# Altium schematic sheet Sway Rev C - Sheet 2.SchDoc("Sway Rev C - Sheet 2")
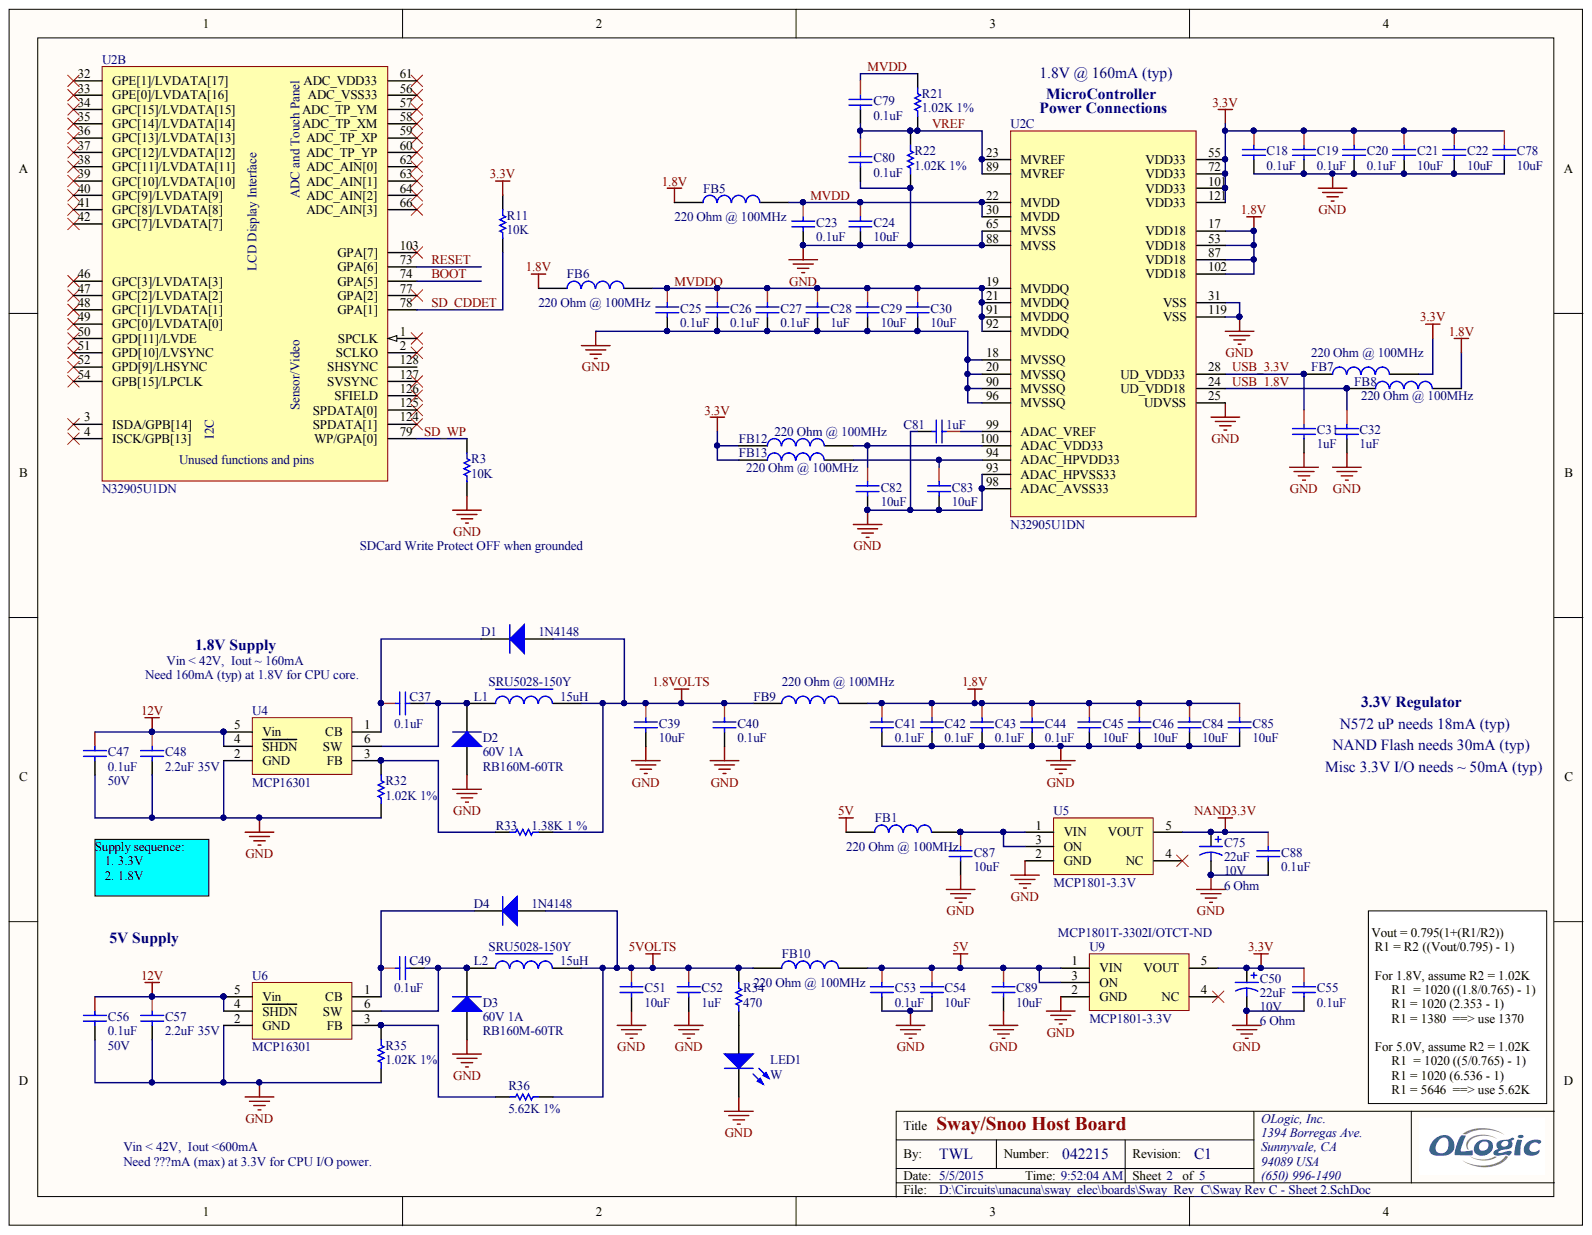
[diagram p0: Sway Rev C - Sheet 2.SchDoc]

## Parts
C18  0.1uF  [CAPC0603(1608)_L]
C19  0.1uF  [CAPC0603(1608)_L]
C20  0.1uF  [CAPC0603(1608)_L]
C21  10uF  [CAPC0805(2012)_L]
C22  10uF  [CAPC0805(2012)_L]
C23  0.1uF  [CAPC0603(1608)_L]
C24  10uF  [CAPC0805(2012)_L]
C25  0.1uF  [CAPC0603(1608)_L]
C26  0.1uF  [CAPC0603(1608)_L]
C27  0.1uF  [CAPC0603(1608)_L]
C28  1uF  [CAPC0603(1608)_L]
C29  10uF  [CAPC0805(2012)_L]
C30  10uF  [CAPC0805(2012)_L]
C31  1uF  [CAPC0603(1608)_L]
C32  1uF  [CAPC0603(1608)_L]
C37  0.1uF  [CAPC0603(1608)_L]
C39  10uF  [CAPC0805(2012)_L]
C40  0.1uF  [CAPC0603(1608)_L]
C41  0.1uF  [CAPC0603(1608)_L]
C42  0.1uF  [CAPC0603(1608)_L]
C43  0.1uF  [CAPC0603(1608)_L]
C44  0.1uF  [CAPC0603(1608)_L]
C45  10uF  [CAPC0805(2012)_L]
C46  10uF  [CAPC0805(2012)_L]
C47  0.1uF  Voltage - Rated: 50V  [CAPC0603(1608)_N]
C48  2.2uF 35V  [CAPC1210(3225)_L]
C49  0.1uF  [CAPC0603(1608)_L]
C50  22uF  Voltage - Rated: 10V  [TANT-3216]
C51  10uF  [CAPC0805(2012)_L]
C52  1uF  [CAPC0603(1608)_L]
C53  0.1uF  [CAPC0603(1608)_L]
C54  10uF  [CAPC0805(2012)_L]
C55  0.1uF  [CAPC0603(1608)_L]
C56  0.1uF  Voltage - Rated: 50V  [CAPC0603(1608)_N]
C57  2.2uF 35V  [CAPC1210(3225)_L]
C75  22uF  ClassName: NAND3.3V  Voltage - Rated: 10V  [TANT-3216]
C78  10uF  [CAPC0805(2012)_L]
C79  0.1uF  [CAPC0603(1608)_L]
C80  0.1uF  [CAPC0603(1608)_L]
C81  1uF  [CAPC0603(1608)_L]
C82  10uF  [CAPC0805(2012)_L]
C83  10uF  [CAPC0805(2012)_L]
C84  10uF  [CAPC0805(2012)_L]
C85  10uF  [CAPC0805(2012)_L]
C87  10uF  [CAPC0805(2012)_L]
C88  0.1uF  [CAPC0603(1608)_L]
C89  10uF  [CAPC0805(2012)_L]
D1  1N4148  [SOD123]
D2  60V 1A  Manufacturer Part Number: RB160M-60TR  Voltage - DC Reverse (Vr) (Max): 60V  [SOD123]
D3  60V 1A  Manufacturer Part Number: RB160M-60TR  Voltage - DC Reverse (Vr) (Max): 60V  [SOD123]
D4  1N4148  [SOD123]
FB1  220 Ohm @ 100MHz  [RES-1608[0603]]
FB5  220 Ohm @ 100MHz  [RES-1608[0603]]
FB6  220 Ohm @ 100MHz  [RES-1608[0603]]
FB7  220 Ohm @ 100MHz  [RES-1608[0603]]
FB8  220 Ohm @ 100MHz  [RES-1608[0603]]
FB9  220 Ohm @ 100MHz  [RES-1608[0603]]
FB10  220 Ohm @ 100MHz  [RES-1608[0603]]
FB12  220 Ohm @ 100MHz  [RES-1608[0603]]
FB13  220 Ohm @ 100MHz  [RES-1608[0603]]
L1  15uH  Manufacturer Part Number: SRU5028-150Y  [INDUCTOR 5.2x5.2mm SMD]
L2  15uH  Manufacturer Part Number: SRU5028-150Y  [INDUCTOR 5.2x5.2mm SMD]
LED1  W  [SM-LED-0603]
R3  10K  [RESC0603(1608)_N]
R11  10K  [RESC0603(1608)_N]
R21  1.02K 1%  [RESC0603(1608)_N]
R22  1.02K 1%  [RESC0603(1608)_N]
R32  1.02K 1%  [RESC0603(1608)_L]
R33  1.38K  Tolerance: 1 %  [RESC0603(1608)_L]
R34  470  [RESC0603(1608)_L]
R35  1.02K 1%  [RESC0603(1608)_L]
R36  5.62K 1%  [RESC0603(1608)_L]
U2  N32905U1DN  [LQFP128]  (parts B,C)
U4  MCP16301  [SOT23-6]
U5  MCP1801-3.3V  ClassName: NAND3.3V  [SOT23-5]
U6  MCP16301  [SOT23-6]
U9  MCP1801-3.3V  PN: MCP1801T-3302I/OTCT-ND  [SOT23-5]

## Nets
1.8V: C41.1 C42.1 C43.1 C44.1 C45.1 C46.1 C84.1 C85.1 FB5.1 FB6.1 FB8.2 FB9.2 U2.17(VDD18) U2.53(VDD18) U2.87(VDD18) U2.102(VDD18)
1.8VOLTS: C39.1 C40.1 D1.1 FB9.1 L1.3 R33.1
3.3V: C18.1 C19.1 C20.1 C21.1 C22.1 C50.1 C55.1 C78.1 FB7.2 FB12.1 FB13.1 R11.2 U2.55(VDD33) U2.72(VDD33) U2.101(VDD33) U2.121(VDD33) U9.5(VOUT)
5V: C53.1 C54.1 C89.1 FB1.1 FB10.2 U9.1(VIN) U9.3(ON)
5VOLTS: C51.1 C52.1 D4.1 FB10.1 L2.3 R34.2 R36.1
12V: C47.1 C48.1 C56.1 C57.1 U4.4(SHDN) U4.5(Vin) U6.4(SHDN) U6.5(Vin)
GND: C18.2 C19.2 C20.2 C21.2 C22.2 C23.2 C24.2 C25.2 C26.2 C27.2 C28.2 C29.2 C30.2 C31.2 C32.2 C39.2 C40.2 C41.2 C42.2 C43.2 C44.2 C45.2 C46.2 C47.2 C48.2 C50.2 C51.2 C52.2 C53.2 C54.2 C55.2 C56.2 C57.2 C75.2 C78.2 C80.2 C81.2 C82.2 C83.2 C84.2 C85.2 C87.2 C88.2 C89.2 D2.1 D3.1 LED1.2 R3.1 R22.1 R32.1 R35.1 U2.18(MVSSQ) U2.20(MVSSQ) U2.25(UDVSS) U2.31(VSS) U2.65(MVSS) U2.88(MVSS) U2.90(MVSSQ) U2.93(ADAC_HPVSS33) U2.96(MVSSQ) U2.98(ADAC_AVSS33) U2.119(VSS) U4.2(GND) U5.2(GND) U6.2(GND) U9.2(GND)
NAND3.3V: C75.1 C88.1 U5.5(VOUT)
BOOT: U2.74(GPA[5])
MVDD: C23.1 C24.1 C79.1 FB5.2 R21.2 U2.22(MVDD) U2.30(MVDD)
MVDDQ: C25.1 C26.1 C27.1 C28.1 C29.1 C30.1 FB6.2 U2.19(MVDDQ) U2.21(MVDDQ) U2.91(MVDDQ) U2.92(MVDDQ)
RESET: U2.73(GPA[6])
SD_CDDET: R11.1 U2.78(GPA[1])
SD_WP: R3.2 U2.79(WP/GPA[0])
USB_1.8V: C32.1 FB8.1 U2.24(UD_VDD18)
USB_3.3V: C31.1 FB7.1 U2.28(UD_VDD33)
VREF: C79.2 C80.1 R21.1 R22.2 U2.23(MVREF) U2.89(MVREF)
NetC37_1: C37.1 D1.2 U4.1(CB)
NetC37_2: C37.2 D2.2 L1.1 U4.6(SW)
NetC49_1: C49.1 D4.2 U6.1(CB)
NetC49_2: C49.2 D3.2 L2.1 U6.6(SW)
NetC81_1: C81.1 U2.99(ADAC_VREF)
NetC82_1: C82.1 FB12.2 U2.100(ADAC_VDD33)
NetC83_1: C83.1 FB13.2 U2.94(ADAC_HPVDD33)
NetC87_1: C87.1 FB1.2 U5.1(VIN) U5.3(ON)
NetLED1_1: LED1.1 R34.1
NetR32_2: R32.2 R33.2 U4.3(FB)
NetR35_2: R35.2 R36.2 U6.3(FB)

Not connected: U2 1(SPCLK) 2(SCLKO) 3(ISDA/GPB[14]) 4(ISCK/GPB[13]) 32(GPE[1]/LVDATA[17]) 33(GPE[0]/LVDATA[16]) 34(GPC[15]/LVDATA[15]) 35(GPC[14]/LVDATA[14]) 36(GPC[13]/LVDATA[13]) 37(GPC[12]/LVDATA[12]) 38(GPC[11]/LVDATA[11]) 39(GPC[10]/LVDATA[10]) 40(GPC[9]/LVDATA[9]) 41(GPC[8]/LVDATA[8]) 42(GPC[7]/LVDATA[7]) 46(GPC[3]/LVDATA[3]) 47(GPC[2]/LVDATA[2]) 48(GPC[1]/LVDATA[1]) 49(GPC[0]/LVDATA[0]) 50(GPD[11]/LVDE) 51(GPD[10]/LVSYNC) 52(GPD[9]/LHSYNC) 54(GPB[15]/LPCLK) 56(ADC_VSS33) 57(ADC_TP_YM) 58(ADC_TP_XM) 59(ADC_TP_XP) 60(ADC_TP_YP) 61(ADC_VDD33) 62(ADC_AIN[0]) 63(ADC_AIN[1]) 64(ADC_AIN[2]) 66(ADC_AIN[3]) 77(GPA[2]) 103(GPA[7]) 124(SPDATA[1]) 125(SPDATA[0]) 126(SFIELD) 127(SVSYNC) 128(SHSYNC); U5 4(NC); U9 4(NC)

## Library data of the parts
C18-C20 C23 C25-C27 C37 C40-C44 C49 C53 C55 C79 C80 C88 = CAP CER 0.1UF 16V 10% X7R 0603: 0.1uF 0603; Manufacturer: Kemet; Manufacturer Part Number: C0603C104K4RACTU; Capacitance: 0.1µF; Temperature Coefficient: X7R; Tolerance: ±10%; Voltage - Rated: 16V; Applications: General Purpose; Category: Capacitors; Component Kind: Standard; ComponentLink1Description: http://www.kemet.com/datasheets&C0603C104K4RACTU; ComponentLink1URL: http://www.kemet.com/datasheets&C0603C104K4RACTU; Features: -; Height - Seated (Max): -; Lead Spacing: -; Lead Style: -; Library Name: OLogic-Passive.IntLib; Mounting Type: Surface Mount, MLCC; Operating Temperature: -55°C ~ 125°C; Package / Case: 0603 (1608 Metric); Packaging: Tape & Reel (TR); Pricing 1: 4000=0.00414, 8000=0.00378, 12000=0.0036, 28000=0.00342, 100000=0.00248 (USD); Ratings: -; RoHS: RoHS Compliant; Size / Dimension: 0.063" L x 0.031" W (1.60mm x 0.80mm); Stock 1: 2360000; Supplier 1: Digi-Key; Supplier Part Number 1: 399-1096-2-ND; Thickness (Max): 0.034" (0.87mm)
  C18-C20 C23 C25-C27 C41-C44 C55 C79 C80 C88: ClassName: UP
  C37 C49 C53: ClassName: PWR
C21 C22 C24 C29 C30 C39 C45 C46 C51 C54 C78 C82-C85 C87 C89 = CAP CER 10UF 10V Y5V 0805: 10uF 0805; Manufacturer: Taiyo Yuden; Manufacturer Part Number: LMK212F106ZG-T; Capacitance: 10µF; Temperature Coefficient: Y5V (F); Tolerance: -20%, +80%; Voltage - Rated: 10V; Applications: General Purpose; Category: Capacitors; Component Kind: Standard; ComponentLink1Description: http://www.yuden.co.jp/or/product/category/capacitor/LMK212F106ZG-T.pdf; ComponentLink1URL: http://www.yuden.co.jp/or/product/category/capacitor/LMK212F106ZG-T.pdf; Features: -; Height - Seated (Max): -; Lead Spacing: -; Lead Style: -; Library Name: OLogic-Passive.IntLib; Mounting Type: Surface Mount, MLCC; Operating Temperature: -30°C ~ 85°C; Package / Case: 0805 (2012 Metric); Packaging: Cut Tape (CT); Pricing 1: 1=0.17, 10=0.115, 50=0.0662, 100=0.0546, 250=0.046, 500=0.03852, 1000=0.03163 (USD); Ratings: -; RoHS: RoHS Compliant; Size / Dimension: 0.079" L x 0.049" W (2.00mm x 1.25mm); Stock 1: 194456; Supplier 1: Digi-Key; Supplier Part Number 1: 587-1312-1-ND; Thickness (Max): 0.053" (1.35mm)
  C21 C22 C24 C29 C30 C45 C46 C78 C82-C85: ClassName: UP
  C39 C51 C54: ClassName: PWR
  C87: ClassName: NAND3.3V
  C89: ClassName: 3_3V
C28 C31 C32 C52 C81 = CAP CER 1UF 10V 10% X5R 0603: 1uF 0603; Manufacturer: Kemet; Manufacturer Part Number: C0603C105K8PACTU; Capacitance: 1.0µF; Temperature Coefficient: X5R; Tolerance: ±10%; Voltage - Rated: 10V; Applications: General Purpose; Category: Capacitors; Component Kind: Standard; ComponentLink1Description: http://www.kemet.com/datasheets&C0603C105K8PACTU; ComponentLink1URL: http://www.kemet.com/datasheets&C0603C105K8PACTU; Features: -; Height - Seated (Max): -; Lead Spacing: -; Lead Style: -; Library Name: OLogic-Passive.IntLib; Mounting Type: Surface Mount, MLCC; Operating Temperature: -55°C ~ 85°C; Package / Case: 0603 (1608 Metric); Packaging: Cut Tape (CT); Pricing 1: 1=0.1, 10=0.043, 25=0.0304, 50=0.0232, 100=0.0196, 250=0.01608, 1000=0.0105 (USD); Ratings: -; RoHS: RoHS Compliant; Size / Dimension: 0.063" L x 0.031" W (1.60mm x 0.80mm); Stock 1: 1121198; Supplier 1: Digi-Key; Supplier Part Number 1: 399-3118-1-ND; Thickness (Max): 0.035" (0.90mm)
  C28 C31 C32 C81: ClassName: UP
  C52: ClassName: PWR
C47 C56 = CAP CER 0.1UF 50V 10% X7R 0603: 0.1UF 50V 0603; Manufacturer: Kemet; Manufacturer Part Number: C0603C104K5RACTU; Capacitance: 0.1µF; Temperature Coefficient: X7R; Tolerance: ±10%; Applications: General Purpose; Category: Capacitors; Component Kind: Standard; ComponentLink1Description: http://www.kemet.com/docfinder?Partnumber=C0603C104K5RACTU; ComponentLink1URL: http://www.kemet.com/docfinder?Partnumber=C0603C104K5RACTU; Features: -; Height - Seated (Max): -; Lead Spacing: -; Lead Style: -; Library Name: OLogic-Passive.IntLib; Mounting Type: Surface Mount, MLCC; Operating Temperature: -55°C ~ 125°C; Package / Case: 0603 (1608 Metric); Packaging: Cut Tape (CT); Pricing 1: 1=0.1, 10=0.041, 50=0.0222, 100=0.0187, 250=0.01532, 500=0.0131, 1000=0.0102 (USD); Ratings: -; RoHS: RoHS Compliant; Size / Dimension: 0.063" L x 0.031" W (1.60mm x 0.80mm); Stock 1: 1397942; Supplier 1: Digi-Key; Supplier Part Number 1: 399-5089-1-ND; Thickness (Max): 0.034" (0.87mm)
C48 C57 = CAP CER 2.2UF 35V 10% X5R 1210: 2.2uF 1210; Manufacturer: Taiyo Yuden; Manufacturer Part Number: GMK325BJ225KN-T; Capacitance: 2.2µF; Temperature Coefficient: X5R; Tolerance: ±10%; Applications: General Purpose; Category: Capacitors; ClassName: PWR; Component Kind: Standard; ComponentLink1Description: http://www.yuden.co.jp/productdata/catalog/en/mlcc101_e.pdf; ComponentLink1URL: http://www.yuden.co.jp/productdata/catalog/en/mlcc101_e.pdf; Features: -; Height - Seated (Max): -; Lead Spacing: -; Lead Style: -; Library Name: OLogic-Passive.IntLib; Mounting Type: Surface Mount, MLCC; Operating Temperature: -55°C ~ 85°C; Package / Case: 1210 (3225 Metric); Packaging: Cut Tape (CT); Pricing 1: 1=0.38, 10=0.329, 50=0.1998, 100=0.1645, 250=0.1316, 500=0.11986, 1000=0.1081 (USD); Ratings: -; RoHS: RoHS Compliant; Size / Dimension: 0.126" L x 0.098" W (3.20mm x 2.50mm); Stock 1: 72591; Supplier 1: Digi-Key; Supplier Part Number 1: 587-1372-1-ND; Thickness (Max): 0.083" (2.10mm); Voltage - Rated: 35V
C50 C75 = CAP TANT 22UF 10V 20% 1206: 22uF 3216 10V 6 ohms; Manufacturer: Kemet; Manufacturer Part Number: T491A226M010AT; Manufacturer Size Code: A; Capacitance: 22µF; ESR (Equivalent Series Resistance): 6 Ohm; Tolerance: ±20%; Type: Molded; Category: Capacitors; Component Kind: Standard; ComponentLink1Description: http://www.kemet.com/kemet/web/homepage/kechome.nsf/file/T491%20Series/$file/KEM_T2005_T491.pdf; ComponentLink1URL: http://www.kemet.com/kemet/web/homepage/kechome.nsf/file/T491%20Series/$file/KEM_T2005_T491.pdf; Features: General Purpose; Height - Seated (Max): 0.071" (1.80mm); Lead Spacing: -; Library Name: OLogic-Passive.IntLib; Lifetime @ Temp.: -; Mounting Type: Surface Mount; Operating Temperature: -55°C ~ 125°C; Package / Case: 1206 (3216 Metric); Packaging: Cut Tape (CT); Pricing 1: 1=0.75, 10=0.714, 25=0.5064, 50=0.4264, 100=0.3464, 250=0.2878, 1000=0.23513 (USD); RoHS: RoHS Compliant; Size / Dimension: 0.126" L x 0.063" W (3.20mm x 1.60mm); Stock 1: 32923; Supplier 1: Digi-Key; Supplier Part Number 1: 399-3692-1-ND
  C50: ClassName: 3_3V
D1 D4 = 1N4148WS: DIODE SML SIG 75V 0.15A SOD323F; Manufacturer: Fairchild Semiconductor; Capacitance @ Vr, F: 4pF @ 0V, 1MHz; Current - Average Rectified (Io): 150mA; Current - Reverse Leakage @ Vr: 5µA @ 75V; Diode Type: Standard; Reverse Recovery Time (trr): 4ns; Speed: Small Signal =< 200mA (Io), Any Speed; Voltage - Forward (Vf) (Max) @ If: 1V @ 10mA; Category: Discrete Semiconductor Products; Component Kind: Standard; ComponentLink1Description: http://www.fairchildsemi.com/datasheets/1N/1N4148WS.pdf; ComponentLink1URL: http://www.fairchildsemi.com/datasheets/1N/1N4148WS.pdf; Library Name: OLogic-Diode-Opto-LED.IntLib; Manufacturer Part Number: 1N4148WS; Mounting Type: Surface Mount; Operating Temperature - Junction: -55°C ~ 150°C; Package / Case: SC-90, SOD-323F; Packaging: Cut Tape (CT); Pricing 1: 1=0.13, 10=0.12, 25=0.1096, 100=0.0788, 250=0.0464, 500=0.0385, 1000=0.02627 (USD); RoHS: RoHS Compliant; Stock 1: 245244; Supplier 1: Digi-Key; Supplier Device Package: SOD-323F; Supplier Part Number 1: 1N4148WSFSCT-ND; Voltage - DC Reverse (Vr) (Max): 75V
D2 D3 = DIODE SCHOTTKY 60V 1A: Rohm switching regulator diode; Manufacturer: Rohm Semiconductor; Capacitance @ Vr, F: 40pF @ 10V, 1MHz; Current - Reverse Leakage @ Vr: 50µA @ 60V; Speed: Fast Recovery =< 500ns, > 200mA (Io); Voltage - Forward (Vf) (Max) @ If: 550mV @ 1A; Category: Discrete Semiconductor Products; ClassName: PWR; Component Kind: Standard; ComponentLink1Description: http://rohmfs.rohm.com/en/products/databook/datasheet/discrete/diode/schottky_barrier/rb160m-60.pdf; ComponentLink1URL: http://rohmfs.rohm.com/en/products/databook/datasheet/discrete/diode/schottky_barrier/rb160m-60.pdf; Current - Average Rectified (Io): 1A; Diode Type: Schottky; Library Name: OLogic-Diode-Opto-LED.IntLib; Mounting Type: Surface Mount; Operating Temperature - Junction: 150°C (Max); Package / Case: SOD-123F; Packaging: Cut Tape (CT); Pricing 1: 1=0.41, 10=0.318, 25=0.268, 100=0.2184, 250=0.18088, 500=0.14944, 1000=0.11193 (USD); Reverse Recovery Time (trr): -; RoHS: RoHS Compliant; Stock 1: 83463; Supplier 1: Digi-Key; Supplier Device Package: PMDU; Supplier Part Number 1: RB160M-60CT-ND; Thermal Resistance: -
FB1 FB5-FB10 FB12 FB13 = FERRITE CHIP 220 OHMS 2.0A 0603: 220 0603 50 mOhms 2A; Manufacturer: Laird-Signal Integrity Products; Manufacturer Part Number: MI0603L221R-10; DC Resistance (DCR): 50 mOhm Max; Filter Type: Differential Mode - Single; Category: Filters; Component Kind: Standard; ComponentLink1Description: http://www.lairdtech.com/WorkArea/linkit.aspx?LinkIdentifier=id&ItemID=5724; ComponentLink1URL: http://www.lairdtech.com/WorkArea/linkit.aspx?LinkIdentifier=id&ItemID=5724; Current Rating: 2A; Height (Max): 0.037" (0.95mm); Impedance @ Frequency: 220 Ohm @ 100MHz; Library Name: OLogic-Passive.IntLib; Mounting Type: Surface Mount; Package / Case: 0603 (1608 Metric); Packaging: Cut Tape (CT); Pricing 1: 1=0.1, 10=0.08, 25=0.0732, 50=0.0658, 100=0.0483, 250=0.03512, 500=0.03218, 1000=0.0278 (USD); RoHS: RoHS Compliant; Size / Dimension: 0.063" L x 0.031" W (1.60mm x 0.80mm); Stock 1: 195609; Supplier 1: Digi-Key; Supplier Part Number 1: 240-2558-1-ND
L1 L2 = INDUCTOR 15UH 1.1A SMD: 15uH 5.2x5.2mm; Manufacturer: Bourns Inc.; Current - Saturation: 1A; Current Rating: 1.1A; DC Resistance (DCR): 108 mOhm; Frequency - Self Resonant: 23MHz; Frequency - Test: 100kHz; Inductance: 15µH; Material - Core: Ferrite; Q @ Freq: 18 @ 2.52MHz; Shielding: Shielded; Tolerance: ±30%; Type: Wirewound; Category: Inductors, Coils, Chokes; Component Kind: Standard; ComponentLink1Description: http://www.bourns.com/data/global/pdfs/SRU5028.pdf; ComponentLink1URL: http://www.bourns.com/data/global/pdfs/SRU5028.pdf; Height - Seated (Max): 0.118" (3.00mm); Library Name: OLogic-Passive.IntLib; Mounting Type: Surface Mount; Operating Temperature: -40°C ~ 125°C; Package / Case: Non Standard; Packaging: Cut Tape (CT); Pricing 1: 1=0.64, 10=0.57, 25=0.5344, 50=0.4632, 100=0.4275, 250=0.40612 (USD); RoHS: RoHS Compliant; Size / Dimension: 0.205" L x 0.205" W (5.20mm x 5.20mm); Stock 1: 1038; Supplier 1: Digi-Key; Supplier Device Package: -; Supplier Part Number 1: SRU5028-150YCT-ND
LED1 = LED WHITE 0603 SMD: 0603 SMD WHITE LED; Manufacturer: Lite-On Inc; Manufacturer Part Number: LTW-C193TS5; Color: White, Cool; Current - Test: 5mA; Lens Style/Size: Rectangle with Flat Top, 1.15mm x 0.8mm; Lens Type: Diffused, Tinted; Millicandela Rating: 108.5mcd; Viewing Angle: 130°; Voltage - Forward (Vf) (Typ): 2.93V; Wavelength - Dominant: 8700K; Category: Optoelectronics; Component Kind: Standard; ComponentLink1Description: http://optoelectronics.liteon.com/upload/download/DS22-2004-203/S_110_LTW-C193TS5.pdf; ComponentLink1URL: http://optoelectronics.liteon.com/upload/download/DS22-2004-203/S_110_LTW-C193TS5.pdf; Height: 0.40mm; Library Name: OLogic-Diode-Opto-LED.IntLib; Luminous Flux @ Current - Test: -; Mounting Type: Surface Mount; Package / Case: 0603 (1608 Metric); Packaging: Cut Tape (CT); Pricing 1: 1=0.54, 10=0.418, 100=0.3013, 250=0.26732, 500=0.22842, 1000=0.17982 (USD); RoHS: RoHS Compliant; Size / Dimension: 1.60mm L x 0.80mm W; Stock 1: 193391; Supplier 1: Digi-Key; Supplier Part Number 1: 160-1721-1-ND; Wavelength - Peak: -
R3 R11 = RES 10K OHM 1/10W 5% 0603 SMD: 10K 0603; Manufacturer: Panasonic Electronic Components; Manufacturer Part Number: ERJ-3GEYJ103V; Power (Watts): 0.1W, 1/10W; Temperature Coefficient: ±200ppm/°C; Tolerance: ±5%; Category: Resistors; Component Kind: Standard; ComponentLink1Description: http://industrial.panasonic.com/www-cgi/jvcr13pz.cgi?E+PZ+3+AOA0001+ERJ3GEYJ103V+7+WW; ComponentLink1URL: http://industrial.panasonic.com/www-cgi/jvcr13pz.cgi?E+PZ+3+AOA0001+ERJ3GEYJ103V+7+WW; Composition: Thick Film; Features: -; Height: 0.022" (0.55mm); Library Name: OLogic-Passive.IntLib; Number of Terminations: 2; Package / Case: 0603 (1608 Metric); Packaging: Cut Tape (CT); Pricing 1: 1=0.1, 50=0.0126, 100=0.0096, 250=0.00716, 500=0.00528, 1000=0.00352, 2500=0.00297 (USD); Resistance (Ohms): 10k; RoHS: RoHS Compliant; Signal Integrity: Res; Simulation: RESISTOR; Size / Dimension: 0.063" L x 0.031" W (1.60mm x 0.80mm); Stock 1: 4724855; Supplier 1: Digi-Key; Supplier Device Package: 0603; Supplier Part Number 1: P10KGCT-ND
R21 R22 R32 R35 = RES 1.02K OHM 1/10W 1% 0603 SMD: 1.02K 0603; Manufacturer: Panasonic Electronic Components; Manufacturer Part Number: ERJ-3EKF1021V; Power (Watts): 0.1W, 1/10W; Temperature Coefficient: ±100ppm/°C; Category: Resistors; Component Kind: Standard; ComponentLink1Description: http://industrial.panasonic.com/www-cgi/jvcr13pz.cgi?E+PZ+3+AOA0002+ERJ3EKF1021V+7+WW; ComponentLink1URL: http://industrial.panasonic.com/www-cgi/jvcr13pz.cgi?E+PZ+3+AOA0002+ERJ3EKF1021V+7+WW; Composition: Thick Film; Features: -; Height: 0.022" (0.55mm); Library Name: OLogic-Passive.IntLib; Number of Terminations: 2; Package / Case: 0603 (1608 Metric); Packaging: Cut Tape (CT); Pricing 1: 1=0.1, 50=0.015, 100=0.0114, 250=0.00844, 500=0.00624, 1000=0.00416, 2500=0.00351 (USD); Resistance (Ohms): 1.02k; RoHS: RoHS Compliant; Signal Integrity: Res; Simulation: RESISTOR; Size / Dimension: 0.063" L x 0.031" W (1.60mm x 0.80mm); Stock 1: 36031; Supplier 1: Digi-Key; Supplier Device Package: 0603; Supplier Part Number 1: P1.02KHCT-ND; Tolerance: ±1%
  R32 R35: ClassName: PWR
R33 = RES 1.38k OHM 63mW 1% 0603 SMD: 1.38k 0603 (Mouser); Manufacturer Part Number: RN731JTTD1381F100; Brand: KOA Speer; Case Height: 0.45 mm; Case Length: 1.6 mm; Case Width: 0.8 mm; Power Rating: 63 mW; Resistance: 1.38 kOhms; Temperature Coefficient: 10 PPM / C; Voltage Rating: 50 V; Case Code - in: 0603; Case Code - mm: 1608; Category: Thin Film Resistors - SMD; ClassName: PWR; Component Kind: Standard; ComponentLink1Description: http://www.koaspeer.com/catimages/Products/RN73/RN73.pdf; ComponentLink1URL: http://www.koaspeer.com/catimages/Products/RN73/RN73.pdf; Library Name: OLogic-Passive.IntLib; Manufacturer: KOA Speer; Minimum Order Quantity: 1; Operating Temperature Range: - 55 C to + 125 C; Package / Case: 0603 (1608 metric); Packaging: Reel; Pricing 1: 1=0.176, 50=0.131, 100=0.119, 500=0.108, 1000=0.100, 5000=0.095, 10000=0.089, 15000=0.084, 25000=0.078 (AUD); Product: Precision Resistors Thin Film SMD; Product Category: 0603 SMD Thin Film Chip Resistors; Reeling: True; RoHS: RoHS Compliant; RoHS - Mouser: Y; Series: RN73; Signal Integrity: Res; Simulation: RESISTOR; Standard Pack Qty: 5000; Stock 1: 0; Supplier 1: Mouser; Supplier Part Number 1: 660-RN731JTD1381F100; Type: Thin Film (Metal) Chip Resistor-Ultra High Precision Tolerance
R34 = RES 470 OHM 1/10W 1% 0603 SMD: 470 0603; Manufacturer: Yageo; Manufacturer Part Number: RC0603FR-07470RL; Power (Watts): 0.1W, 1/10W; Temperature Coefficient: ±100ppm/°C; Tolerance: ±1%; Category: Resistors; ClassName: PWR; Component Kind: Standard; ComponentLink1Description: http://www.yageo.com/documents/recent/PYu-RC0603_51_RoHS_L_4.pdf; ComponentLink1URL: http://www.yageo.com/documents/recent/PYu-RC0603_51_RoHS_L_4.pdf; Composition: Thick Film; Features: -; Height: 0.022" (0.55mm); Library Name: OLogic-Passive.IntLib; Number of Terminations: 2; Package / Case: 0603 (1608 Metric); Packaging: Cut Tape (CT); Pricing 1: 1=0.1, 10=0.015, 25=0.0108, 100=0.006, 250=0.00456, 500=0.00364, 1000=0.00268, 2500=0.00233 (USD); Resistance (Ohms): 470; RoHS: RoHS Compliant; Signal Integrity: Res; Simulation: RESISTOR; Size / Dimension: 0.063" L x 0.031" W (1.60mm x 0.80mm); Stock 1: 184849; Supplier 1: Digi-Key; Supplier Device Package: 0603; Supplier Part Number 1: 311-470HRCT-ND
R36 = RES 5.62K OHM 1/10W 1% 0603 SMD: 5.62K 0603 1%; Manufacturer: Panasonic Electronic Components; Manufacturer Part Number: ERJ-3EKF5621V; Power (Watts): 0.1W, 1/10W; Temperature Coefficient: ±100ppm/°C; Category: Resistors; ClassName: PWR; Component Kind: Standard; ComponentLink1Description: http://industrial.panasonic.com/www-cgi/jvcr13pz.cgi?E+PZ+3+AOA0002+ERJ3EKF5621V+7+WW; ComponentLink1URL: http://industrial.panasonic.com/www-cgi/jvcr13pz.cgi?E+PZ+3+AOA0002+ERJ3EKF5621V+7+WW; Composition: Thick Film; Features: -; Height: 0.022" (0.55mm); Library Name: OLogic-Passive.IntLib; Number of Terminations: 2; Package / Case: 0603 (1608 Metric); Packaging: Cut Tape (CT); Pricing 1: 1=0.1, 50=0.015, 100=0.0114, 250=0.00844, 500=0.00624, 1000=0.00416, 2500=0.00351 (USD); Resistance (Ohms): 5.62k; RoHS: RoHS Compliant; Signal Integrity: Res; Simulation: RESISTOR; Size / Dimension: 0.063" L x 0.031" W (1.60mm x 0.80mm); Stock 1: 144881; Supplier 1: Digi-Key; Supplier Device Package: 0603; Supplier Part Number 1: P5.62KHCT-ND; Tolerance: ±1%
U2 = N32905U1DN: ARM926-based Media Processor; Component Kind: Standard; Library Name: OLogic-CPU-Memory.IntLib
U4 U6 = MCP16301: IC REG BUCK ADJ 0.6A SOT23-6; Manufacturer: Microchip Technology; Manufacturer Part Number: MCP16301T-I/CHY; Current - Output: 600mA; Frequency - Switching: 500kHz; Number of Outputs: 1; Output Type: Adjustable; Synchronous Rectifier: No; Voltage - Input: 4 V ~ 30 V; Voltage - Output: 2 V ~ 15 V; Category: Integrated Circuits (ICs); Component Kind: Standard; ComponentLink1Description: http://www.microchip.com/mymicrochip/filehandler.aspx?ddocname=en554098; ComponentLink1URL: http://www.microchip.com/mymicrochip/filehandler.aspx?ddocname=en554098; Mounting Type: Surface Mount; Operating Temperature: -40°C ~ 85°C; Package / Case: SOT-23-6; Packaging: Cut Tape (CT); Pricing 1: 1=1.21, 10=1.01, 25=0.84, 100=0.76 (USD); RoHS: RoHS Compliant; Stock 1: 0; Supplier 1: Digi-Key; Supplier Device Package: SOT-23-6; Supplier Part Number 1: MCP16301T-I/CHYCT-ND; Type: Step-Down (Buck); Library Name: OLogic-Reg-Convert.IntLib
U5 = MCP1801: 3.3V 150ma LDO; Manufacturer: Microchip; Manufacturer Part Number: MCP1801T-3302I/OT; Number of Regulators: 1; PN: MCP1801T-3302I/OTCT-ND; Regulator Topology: Positive Fixed; Vendor: Digikey; Voltage - Dropout (Typical): 0.2V @ 100mA; Voltage - Input: Up to 10V; Category: Integrated Circuits (ICs); Component Kind: Standard; ComponentLink1Description: http://www.microchip.com/mymicrochip/filehandler.aspx?ddocname=en531339; ComponentLink1URL: http://www.microchip.com/mymicrochip/filehandler.aspx?ddocname=en531339; Current - Limit (Min): -; Current - Output: 150mA; Mounting Type: Surface Mount; MPN: MCP1801T-3302I/OT; Operating Temperature: -40°C ~ 85°C; Package / Case: SC-74A, SOT-753; Packaging: Cut Tape (CT); Pricing 1: 1=0.5, 10=0.42, 25=0.35, 100=0.32 (USD); RoHS: RoHS Compliant; Stock 1: 23784; Supplier 1: Digi-Key; Supplier Device Package: SOT-23-5; Supplier Part Number 1: MCP1801T-3302I/OTCT-ND; Voltage - Output: 3.3V; Library Name: OLogic-Reg-Convert.IntLib
U9 = MCP1801: 3.3V 150ma LDO; Manufacturer: Microchip; Manufacturer Part Number: MCP1801T-3302I/OT; Number of Regulators: 1; Regulator Topology: Positive Fixed; Vendor: Digikey; Voltage - Dropout (Typical): 0.2V @ 100mA; Voltage - Input: Up to 10V; Category: Integrated Circuits (ICs); ClassName: 3_3V; Component Kind: Standard; ComponentLink1Description: http://www.microchip.com/mymicrochip/filehandler.aspx?ddocname=en531339; ComponentLink1URL: http://www.microchip.com/mymicrochip/filehandler.aspx?ddocname=en531339; Current - Limit (Min): -; Current - Output: 150mA; Mounting Type: Surface Mount; MPN: MCP1801T-3302I/OT; Operating Temperature: -40°C ~ 85°C; Package / Case: SC-74A, SOT-753; Packaging: Cut Tape (CT); Pricing 1: 1=0.5, 10=0.42, 25=0.35, 100=0.32 (USD); RoHS: RoHS Compliant; Stock 1: 23784; Supplier 1: Digi-Key; Supplier Device Package: SOT-23-5; Supplier Part Number 1: MCP1801T-3302I/OTCT-ND; Voltage - Output: 3.3V; Library Name: OLogic-Reg-Convert.IntLib
